# Altium schematic sheet simple music keyboard.SchDoc
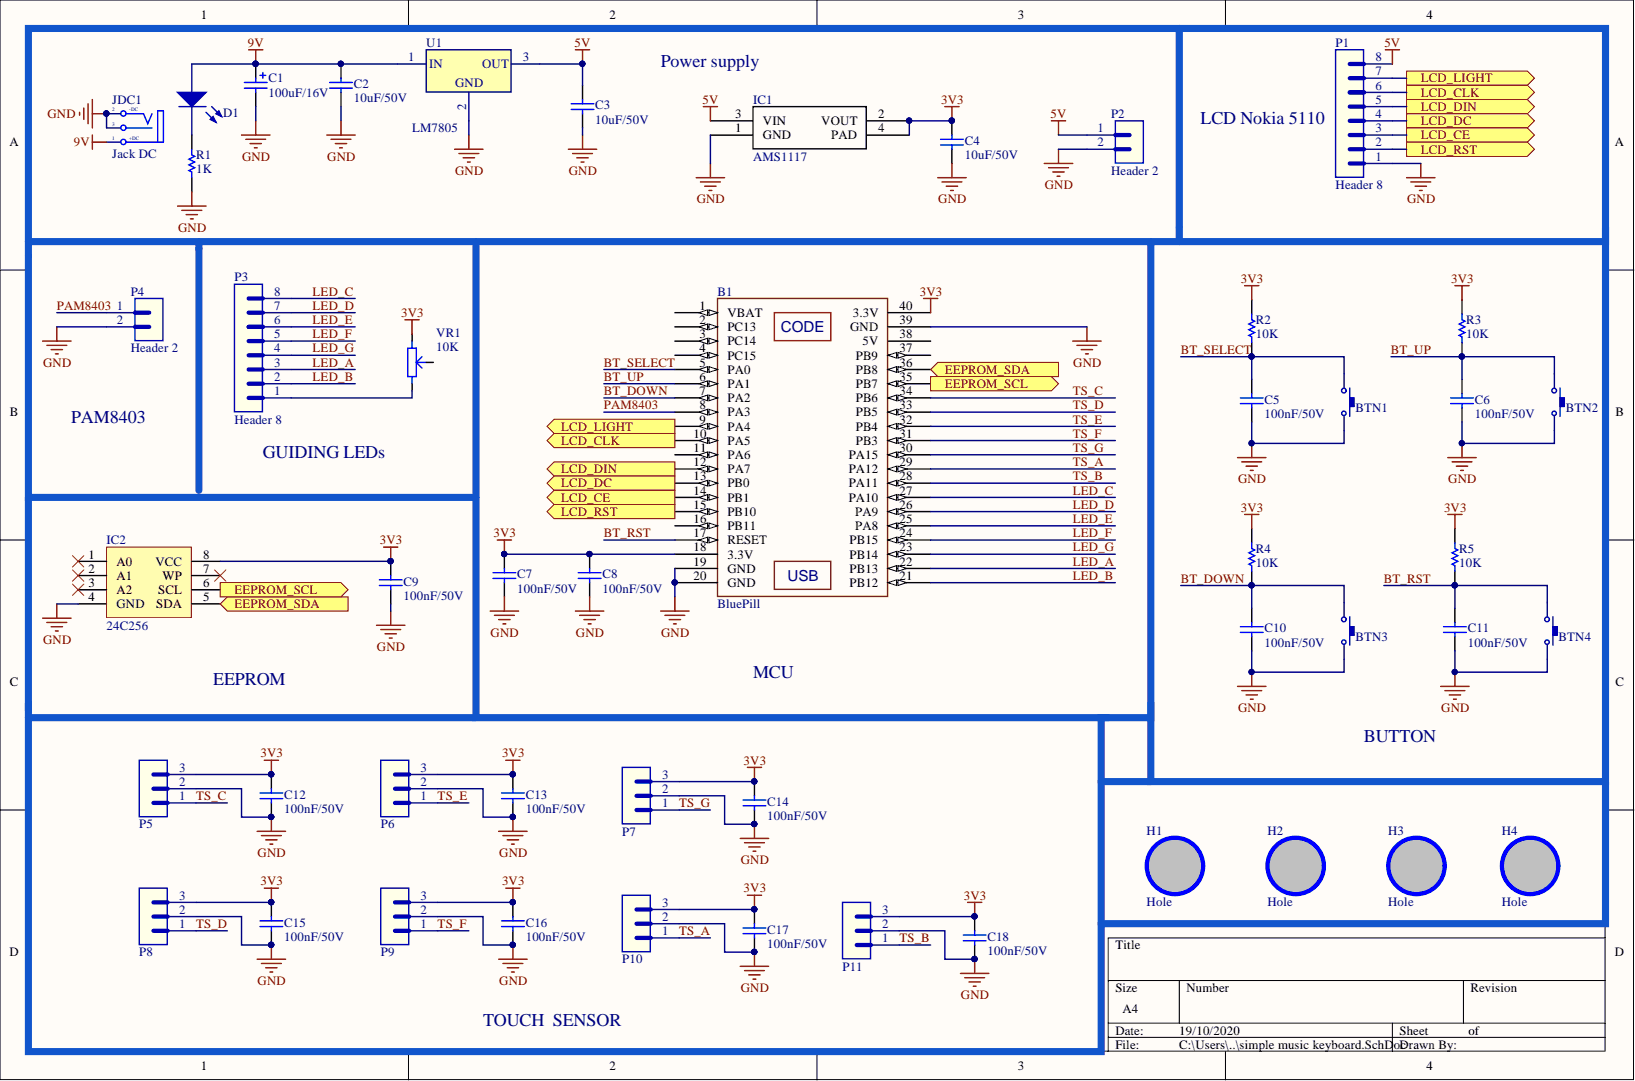
[diagram p0: simple music keyboard.SchDoc]

## Parts
B1  BluePill  [BlUEPILL]
BTN1  Button  [Button]  (value not drawn)
BTN2  Button  [Button]  (value not drawn)
BTN3  Button  [Button]  (value not drawn)
BTN4  Button  [Button]  (value not drawn)
C1  value 100uF/16V  [CAP-SM-AL-SIZE-D-D6L6]  (hidden comment: Cap-Al-100uF-16V-D6L5)
C2  value 10uF/50V  [CAP-SM-0805]  (hidden comment: Cap-10uF-50V-0805)
C3  value 10uF/50V  [CAP-SM-0805]  (hidden comment: Cap-10uF-50V-0805)
C4  value 10uF/50V  [CAP-SM-0805]  (hidden comment: Cap-10uF-50V-0805)
C5  value 100nF/50V  [CAP-SM-0805]  (hidden comment: Cap-100nF-50V-0805)
C6  value 100nF/50V  [CAP-SM-0805]  (hidden comment: Cap-100nF-50V-0805)
C7  value 100nF/50V  [CAP-SM-0805]  (hidden comment: Cap-100nF-50V-0805)
C8  value 100nF/50V  [CAP-SM-0805]  (hidden comment: Cap-100nF-50V-0805)
C9  value 100nF/50V  [CAP-SM-0805]  (hidden comment: Cap-100nF-50V-0805)
C10  value 100nF/50V  [CAP-SM-0805]  (hidden comment: Cap-100nF-50V-0805)
C11  value 100nF/50V  [CAP-SM-0805]  (hidden comment: Cap-100nF-50V-0805)
C12  value 100nF/50V  [CAP-SM-0805]  (hidden comment: Cap-100nF-50V-0805)
C13  value 100nF/50V  [CAP-SM-0805]  (hidden comment: Cap-100nF-50V-0805)
C14  value 100nF/50V  [CAP-SM-0805]  (hidden comment: Cap-100nF-50V-0805)
C15  value 100nF/50V  [CAP-SM-0805]  (hidden comment: Cap-100nF-50V-0805)
C16  value 100nF/50V  [CAP-SM-0805]  (hidden comment: Cap-100nF-50V-0805)
C17  value 100nF/50V  [CAP-SM-0805]  (hidden comment: Cap-100nF-50V-0805)
C18  value 100nF/50V  [CAP-SM-0805]  (hidden comment: Cap-100nF-50V-0805)
D1  LED  [LED-5mm]  (value not drawn)
H1  Hole  [LO KHOAN 3MM]
H2  Hole  [LO KHOAN 3MM]
H3  Hole  [LO KHOAN 3MM]
H4  Hole  [LO KHOAN 3MM]
IC1  AMS1117  [SOT223_AMS111700]
IC2  24C256  [DIP8/W0.3]
JDC1  Jack DC  [JACK-DC]
P1  Header 8  [JP8]
P2  Header 2  [JP2]
P3  Header 8  [JP8]
P4  Header 2  [JP2]
P5  Header 3  [JP3]  (value not drawn)
P6  Header 3  [JP3]  (value not drawn)
P7  Header 3  [JP3]  (value not drawn)
P8  Header 3  [JP3]  (value not drawn)
P9  Header 3  [JP3]  (value not drawn)
P10  Header 3  [JP3]  (value not drawn)
P11  Header 3  [JP3]  (value not drawn)
R1  value 1K  [RES-SM-0805]  (hidden comment: Res-1K-0805)
R2  value 10K  [RES-SM-0805]  (hidden comment: Res-10k-0805)
R3  value 10K  [RES-SM-0805]  (hidden comment: Res-10k-0805)
R4  value 10K  [RES-SM-0805]  (hidden comment: Res-10k-0805)
R5  value 10K  [RES-SM-0805]  (hidden comment: Res-10k-0805)
U1  LM7805  [QTO-220]
VR1  10K  [VR Tam Giac]

## Ports
EEPROM_SCL, EEPROM_SDA, LCD_CE, LCD_CLK, LCD_DC, LCD_DIN, LCD_LIGHT, LCD_RST

## Nets
3V3: B1.18(3.3V) B1.40(3.3V) C4.2 C7.2 C8.2 C9.2 C12.2 C13.2 C14.2 C15.2 C16.2 C17.2 C18.2 IC1.2(VOUT) IC1.4(PAD) IC2.8(VCC) P5.3 P6.3 P7.3 P8.3 P9.3 P10.3 P11.3 R2.2 R3.2 R4.2 R5.2 VR1.1
5V: C3.2 IC1.3(VIN) P1.8 P2.1 U1.3
9V: C1.1 C2.2 D1.1 JDC1.1 U1.1
GND: B1.19(GND) B1.20(GND) B1.39(GND) BTN1.2 BTN2.2 BTN3.2 BTN4.2 C1.2 C2.1 C3.1 C4.1 C5.1 C6.1 C7.1 C8.1 C9.1 C10.1 C11.1 C12.1 C13.1 C14.1 C15.1 C16.1 C17.1 C18.1 IC1.1(GND) IC2.4(GND) JDC1.2 JDC1.3 P1.1 P2.2 P4.2 P5.2 P6.2 P7.2 P8.2 P9.2 P10.2 P11.2 R1.1 U1.2
BT_DOWN: B1.7(PA2) BTN3.1 C10.2 R4.1
BT_RST: B1.17(RESET) BTN4.1 C11.2 R5.1
BT_SELECT: B1.5(PA0) BTN1.1 C5.2 R2.1
BT_UP: B1.6(PA1) BTN2.1 C6.2 R3.1
EEPROM_SCL: B1.35(PB7) IC2.6(SCL) ; port EEPROM_SCL
EEPROM_SDA: B1.36(PB8) IC2.5(SDA) ; port EEPROM_SDA
LCD_CE: B1.14(PB1) P1.3 ; port LCD_CE
LCD_CLK: B1.10(PA5) P1.6 ; port LCD_CLK
LCD_DC: B1.13(PB0) P1.4 ; port LCD_DC
LCD_DIN: B1.12(PA7) P1.5 ; port LCD_DIN
LCD_LIGHT: B1.9(PA4) P1.7 ; port LCD_LIGHT
LCD_RST: B1.15(PB10) P1.2 ; port LCD_RST
LED_A: B1.22(PB13) P3.3
LED_B: B1.21(PB12) P3.2
LED_C: B1.27(PA10) P3.8
LED_D: B1.26(PA9) P3.7
LED_E: B1.25(PA8) P3.6
LED_F: B1.24(PB15) P3.5
LED_G: B1.23(PB14) P3.4
PAM8403: B1.8(PA3) P4.1
TS_A: B1.29(PA12) P10.1
TS_B: B1.28(PA11) P11.1
TS_C: B1.34(PB6) P5.1
TS_D: B1.33(PB5) P8.1
TS_E: B1.32(PB4) P6.1
TS_F: B1.31(PB3) P9.1
TS_G: B1.30(PA15) P7.1
NetD1_2: D1.2 R1.2
NetP3_1: P3.1 VR1.3

Not connected: B1 1(VBAT) 2(PC13) 3(PC14) 4(PC15) 11(PA6) 16(PB11) 37(PB9) 38(5V); IC2 1(A0) 2(A1) 3(A2) 7(WP); VR1 2

## Library data of the parts
C1 = Cap-Al-100uF-16V-D6L5: CAP ALUM 100UF 20% 16V SMD; Manufacturer: Nichicon; Manufacturer Part Number: UWX1C101MCL1GB; Component Kind: Standard; Library Name: Capacitor.SchLib; Local Supplier: thegioiic; Local Supplier Link: https://thegioiic.com/products/tu-nhom-smd-100uf-16v-d6-3l5-4; Supplier 1: Digikey; Supplier 1 Link: https://www.digikey.com/product-detail/en/nichicon/UWX1C101MCL1GB/493-2105-1-ND/590080; Supplier 1 Part Number: 493-2105-1-ND
C2-C4 = Cap-10uF-50V-0805: CAP CER 10UF 50V X5R 0805; Manufacturer: Yageo; Manufacturer Part Number: CC0805KKX5R9BB106; Component Kind: Standard; Library Name: Capacitor.SchLib; Local Supplier: thegioiic; Local Supplier Link: https://thegioiic.com/products/tu-10uf-50v-0805; Supplier 1: Digikey; Supplier 1 Link: https://www.digikey.com/product-detail/en/yageo/CC0805KKX5R9BB106/311-3499-2-ND/7164383; Supplier 1 Part Number: 311-3499-2-ND
C5-C18 = Cap-100nF-50V-0805: CAP CER 0.1UF 50V Y5V 0805; Manufacturer: Samsung Electro-Mechanics; Manufacturer Part Number: CL21F104ZBCNNNC; Component Kind: Standard; Library Name: Capacitor.SchLib; Local Supplier: thegioiic; Local Supplier Link: https://thegioiic.com/products/tu-100nf-0-1uf-50v-0805; Supplier 1: Digikey; Supplier 1 Link: https://www.digikey.com/product-detail/en/samsung-electro-mechanics/CL21F104ZBCNNNC/1276-1007-1-ND/3889093; Supplier 1 Part Number: 1276-1007-1-ND
H1-H4 = Hole: Hole 3mm; Component Kind: Standard; Library Name: PIF_C16_lib.IntLib
IC1 = AMS1117: Manufacturer_Part_Number: AMS1117-5.0; Built_By: EMA_Kyle; SourceLibrary: Advanced_Monolithic_Systems_2020-02-13; Vendor: Advanced Monolithic Systems; Component Kind: Standard; RefDes: RefDes; Type: DEV; Copyright: Copyright (C) 2018 Accelerated Designs. All rights reserved; Library Name: xecanbang.SchLib
IC2 = 24Cxx_MH: Dán; Don_Vi: Con; Ma_Hang: MH002775; Ten_Linh_Kien: AT24C01 DIP8 EEPROM 1KBIT; Chung_Loai: Dán; Component Kind: Standard; Library Name: THU VIEN SCH.SchLib
JDC1 = Jack DC: Jack DC input; Value: Jac DC; Component Kind: Standard; Library Name: Header-connector.SchLib
P1 P3 = Header 8: Header, 8-Pin; Component Kind: Standard; LatestRevisionDate: 17-Jul-2002; LatestRevisionNote: Re-released for DXP Platform.; Publisher: Altium Limited
  P1: Library Name: Header-connector.SchLib
  P3: Library Name: PIF_C16_lib.IntLib
P2 P4 = Header 2: Header, 2-Pin; Component Kind: Standard; LatestRevisionDate: 17-Jul-2002; LatestRevisionNote: Re-released for DXP Platform.; Library Name: PIF_C16_lib.IntLib; Publisher: Altium Limited
P5-P11 = Header 3: Header, 3-Pin; Component Kind: Standard; LatestRevisionDate: 17-Jul-2002; LatestRevisionNote: Re-released for DXP Platform.; Library Name: Header-connector.SchLib; Publisher: Altium Limited
R1 = Res-1K-0805: RES 1K OHM 1% 1/4W 0805; Manufacturer: Stackpole Electronics Inc; Manufacturer Part Number: RNCP0805FTD1K00; Component Kind: Standard; Library Name: Resistor.SchLib; Local Supplier: thegioiic; Local Supplier Link: https://thegioiic.com/products/dien-tro-1k-ohm-0805-5; Supplier 1: Digikey; Supplier 1 Link: https://www.digikey.com/product-detail/en/stackpole-electronics-inc/RNCP0805FTD1K00/RNCP0805FTD1K00CT-ND/2240568; Supplier 1 Part Number: RNCP0805FTD1K00CT-ND
R2-R5 = Res-10k-0805: RES 10K OHM 1% 1/4W 0805; Manufacturer: Stackpole Electronics Inc; Manufacturer Part Number: RNCP0805FTD10K0; Component Kind: Standard; Library Name: Resistor.SchLib; Local Supplier: thegioiic; Local Supplier Link: https://thegioiic.com/products/dien-tro-10k-ohm-0805-5; Supplier 1: Digikey; Supplier 1 Link: https://www.digikey.com/product-detail/en/stackpole-electronics-inc/RNCP0805FTD10K0/RNCP0805FTD10K0CT-ND/2240601; Supplier 1 Part Number: RNCP0805FTD10K0CT-ND
U1 = LM7805: Positive Voltage Regulator; Component Kind: Standard; Library Name: PIF_C16_lib.IntLib
VR1 = Bien tro tam giac 10K: Cắm; Don_Vi: Con; Ma_Hang: MH000919; Ten_Linh_Kien: Biến Trở Tam Giác 103-10K RM065 (Chân Đứng); Chung_Loai: Cắm; Component Kind: Standard; Library Name: THU VIEN SCH.SchLib
B1 = BluePill: Component Kind: Standard; Library Name: Board.SchLib
BTN1-BTN4 = Button: Component Kind: Standard; Library Name: PIF_C16_lib.IntLib
D1 = LED: Component Kind: Standard; Library Name: PIF_C16_lib.IntLib
